# Altium schematic sheet Gatekeeper_sheet7_mic_LED_V2.1.SchDoc(Gatekeeper_sheet7_mic_LED_V2.1)
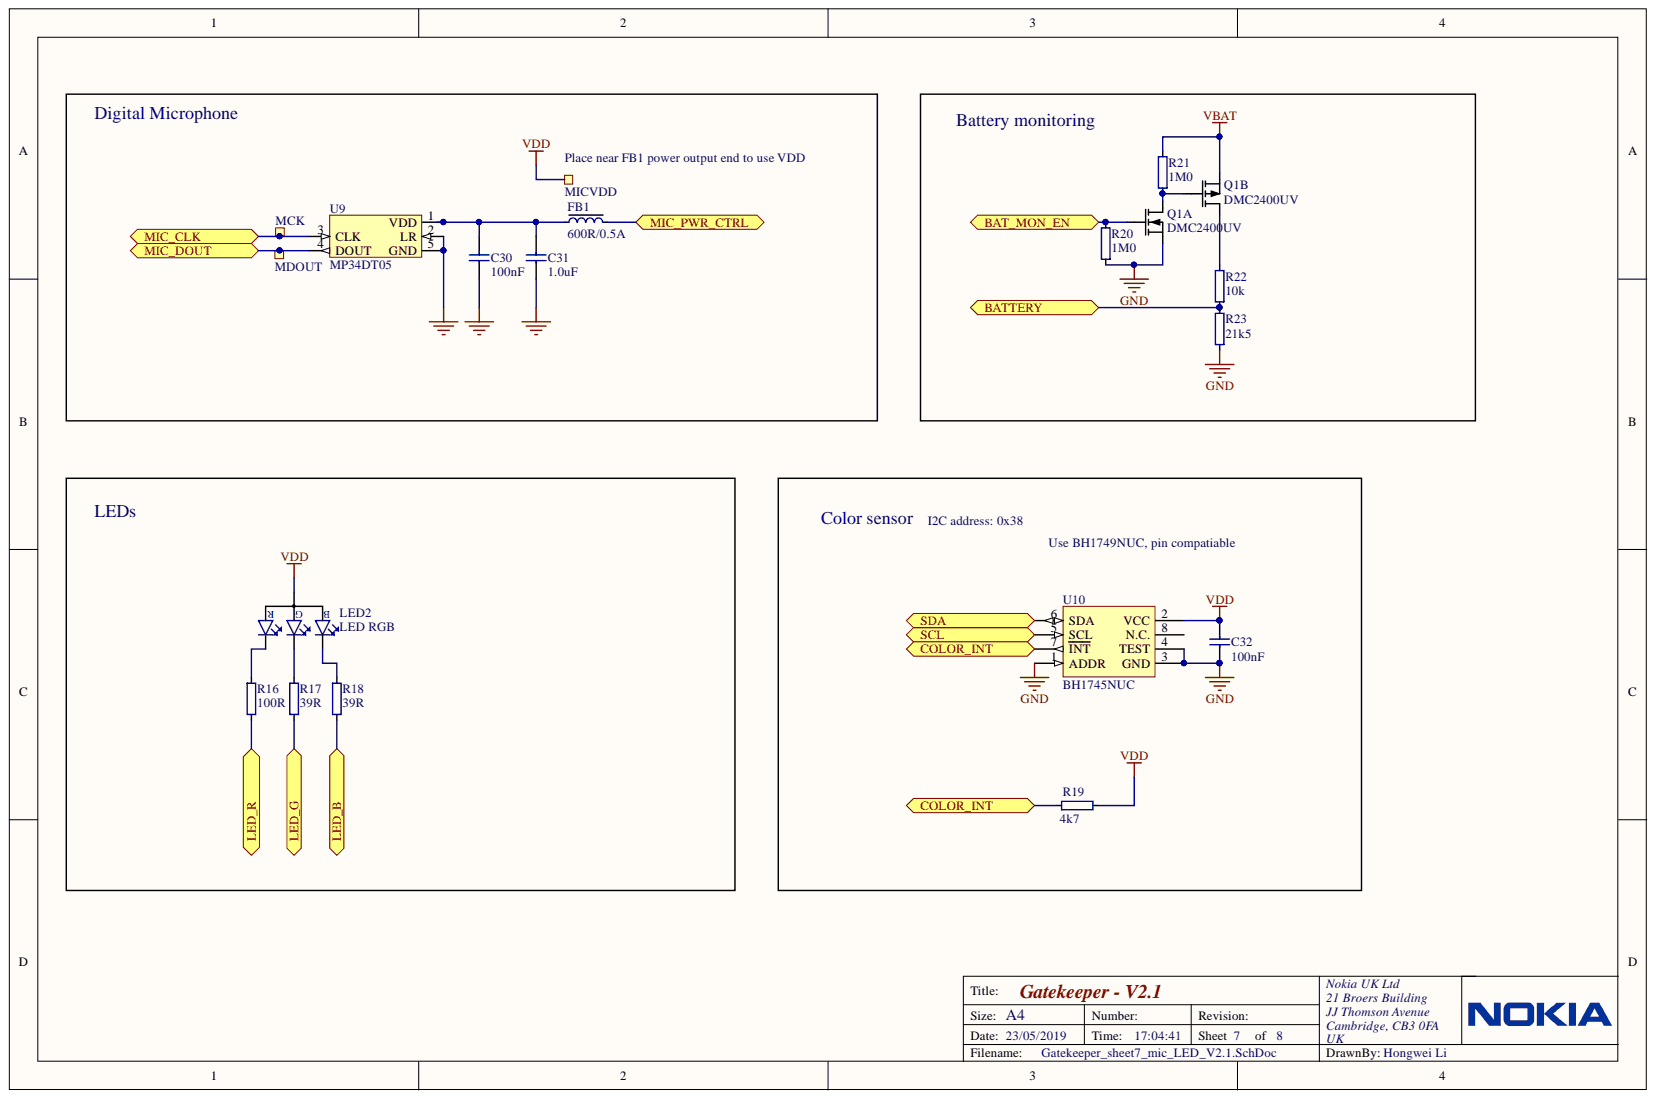
[diagram p0: Gatekeeper_sheet7_mic_LED_V2.1.SchDoc]

## Parts
C30  100nF  [CAPC1005X04L]
C31  1.0uF  [CAPC1608X06L]
C32  100nF  [CAPC1005X04L]
FB1  600R/0.5A  [INDC1608X06L]
LED2  LED RGB  [LED_RGB_0606]
MCK  Ø1.5mm,SMD  [TP_SMD_Ø1.0mm]  (value not drawn)  (no BOM)
MDOUT  Ø1.5mm,SMD  [TP_SMD_Ø1.0mm]  (value not drawn)  (no BOM)
MICVDD  =Value  [TP_SMD_SQ0.8mm]  (no BOM)
Q1  DMC2400UV  [SOTFL50P162X60-6N]  (parts A,B)
R16  100R  [RESC1005X04L]
R17  39R  [RESC1005X04L]
R18  39R  [RESC1005X04L]
R19  4k7  [RESC1005X04L]
R20  1M0  [RESC1005X04L]
R21  1M0  [RESC1005X04L]
R22  10k  [RESC1005X04L]
R23  21k5  [RESC1005X04L]
U9  MP34DT05  [HCLGA-4LD(3x4x1MM)]
U10  BH1745NUC  [WSON50P210X210X60_HS-9N]

## Ports
BAT_MON_EN, BATTERY, COLOR_INT, LED_B, LED_G, LED_R, MIC_CLK, MIC_DOUT, MIC_PWR_CTRL, SCL, SDA

## Nets
GND: C30.1 C31.1 C32.1 Q1.1 R20.2 R23.2 U9.2(LR) U9.5(GND) U10.1(ADDR) U10.3(GND) U10.4(TEST) U10.9
VBAT: Q1.4 R21.1
VDD: C32.2 LED2.4 MICVDD.1 R19.1 U10.2(VCC)
BAT_MON_EN: Q1.2 R20.1 ; port BAT_MON_EN
BATTERY: R22.2 R23.1 ; port BATTERY
COLOR_INT: R19.2 U10.7(INT) ; port COLOR_INT
LED_B: R18.1 ; port LED_B
LED_G: R17.1 ; port LED_G
LED_R: R16.1 ; port LED_R
MIC_CLK: MCK.1 U9.3(CLK) ; port MIC_CLK
MIC_DOUT: MDOUT.1 U9.4(DOUT) ; port MIC_DOUT
MIC_PWR_CTRL: FB1.2 ; port MIC_PWR_CTRL
SCL: U10.5(SCL) ; port SCL
SDA: U10.6(SDA) ; port SDA
NetC30_2: C30.2 C31.2 FB1.1 U9.1(VDD)
NetLED2_1: LED2.1 R16.2
NetLED2_2: LED2.2 R17.2
NetLED2_3: LED2.3 R18.2
NetQ1_3: Q1.3 R22.1
NetQ1_5: Q1.5 Q1.6 R21.2

Not connected: U10 8(N.C.)

## Library data of the parts
C30 C32 = (no symbol name): Capacitor, 0402, X7R, ±10%; Part Number: C0402; Manufacturer Part Number: 885012205037; Manufacturer: Wurth Electronics; 2nd source part number: 81-GCM155R71C104KA5D; Note: 16V; Value: 100nF; Supplier part number: 710-885012205037; Supplier: Mouser; Pin Count: 2; Footprint Doc: 0402; Datasheet: https://www.mouser.co.uk/datasheet/2/445/885012205037-554188.pdf; Component Kind: Standard; 2nd source Supplier: Mouser; Library Name: Gatekeeper.SCHLIB
C31 = (no symbol name): Capacitor, 0603, X7R, ±10%; Part Number: C0603-1µ0; Manufacturer Part Number: JMK107B7105KA-T; Manufacturer: Taiyo Yuden; 2nd source part number: 710-885012206002; Note: 6.3V; Value: 1.0uF; Supplier part number: 963-JMK107B7105KA-T; Supplier: Mouser; Pin Count: 2; Footprint Doc: 0603; Component Kind: Standard; 2nd source Supplier: Mouser; Library Name: Gatekeeper.SCHLIB
FB1 = (no symbol name): Ferrite Bead, 0603, 600 Ohm @ 100MHz, 500mA, 380 mOhm Max; Part number IPS: 00000007789; Part Number: FB0603-600R@100MHz-500mA; Manufacturer Part Number: BLM18AG601SN1D; Manufacturer: Murata Electronics; 2nd source part number: 490-1014-1-ND; Note: Chip EMIFIL Inductor Type Chip Ferrite Bead; Value: 600R/0.5A; Supplier Part Number: 81-BLM11A601S; Supplier: Mouser; Pin Count: 2; Footprint Doc: 0603; Datasheet: http://www.farnell.com/datasheets/356366.pdf; ComponentLink2Description: Supplier link; ComponentLink1Description: Datasheet; Component Kind: Standard; 2nd source Supplier: DigiKey; Library Name: Gatekeeper_V2.1.SchLib
LED2 = D:LED_RGB: RGB LED 1.60 mm x 1.60 mm SMD; Part number IPS: 00000007798; Part Number: LTST-C19HE1WT; Manufacturer: Lite-On Inc; 2nd source part number: 160-2162-1-ND; Value: LED RGB; Supplier Part Number: 859-LTST-C19HE1WT; Supplier: Mouser; Pin Count: 4; Manufacturer Part Number: LTST-C19HE1WT; Footprint Doc: LED_RGB_0606; Datasheet: http://optoelectronics.liteon.com/upload/download/DS22-2008-0044/S_110_LTST-C19HE1WT.pdf; Component Kind: Standard; 2nd Source Supplier: Digi-Key; Library Name: Gatekeeper_V2.1.SchLib
MCK MDOUT = TP_SMD_Ø1.0MM: 1.0mm circular SMD testpad; Part Number: TP_SMD_Ø1.5MM; Value: Ø1.5mm,SMD; Pin Count: 1; Footprint Doc: TP_SMD_Ø1.5mm; Library Name: Gatekeeper.IntLib
  MDOUT: LatestRevisionDate: 2016-06-02
MICVDD = TP_SMD: SMD testpad of various size; Library Name: Gatekeeper_V2.1.SchLib
Q1 = T:FET-N/P-DUAL: Dual N & P Ch MOFET, 1.03A/0.7A, 480mOhm, 450mW; Part Number: DMC2400UV-13; Manufacturer Part Number: DMC2400UV-7; Manufacturer: Diodes Incorporated; 2nd source part number: DMC2400UV-7DICT-ND; Note: Dual N & P Channel Digital FET; Value: DMC2400UV; Supplier part number: 621-DMC2400UV-7; Supplier: Mouser; Pin Count: 6; Footprint Doc: SOT-563; Datasheet: http://www.diodes.com/_files/datasheets/DMC2400UV.pdf; Component Kind: Standard; 2nd source Supplier: DigiKey; Library Name: Gatekeeper.SCHLIB
R16 = (no symbol name): Resistor, 0402, ±1%, 0.063W; Part Number: R0402; Manufacturer Part Number: CRCW0402100RFKEDC; Manufacturer: Vishay; Value Ohm: 100; Note: Chip Res 1%; Value: 100R; Supplier part number: 71-CRCW0402100RFKEDC; Supplier: Mouser; Pin Count: 2; Footprint Doc: 0402; Component Kind: Standard; Library Name: Gatekeeper.SCHLIB
R17 R18 = (no symbol name): Resistor, 0402, ±1%, 0.063W; Part Number: R0402; Manufacturer Part Number: CRCW040239R0FKED; Manufacturer: Vishay; Value Ohm: 39; Note: Chip Res 1%; Value: 39R; Supplier part number: 71-CRCW040239R0FKED; Supplier: Mouser; Pin Count: 2; Footprint Doc: 0402; Component Kind: Standard; Library Name: Gatekeeper.SCHLIB
R19 = (no symbol name): Resistor, 0402, ±1%, 0.063W; Part Number: R0402; Manufacturer Part Number: CRCW04024K70FKEDC; Manufacturer: Vishay; 2nd source part number: AC0402FR-074K7L; Value Ohm: 4700; Note: Chip Res 1%; Value: 4k7; Supplier part number: 71-CRCW04024K70FKEDC; Supplier: Mouser; Pin Count: 2; Footprint Doc: 0402; Datasheet: https://www.mouser.co.uk/datasheet/2/427/crcwce3-1223726.pdf; Component Kind: Standard; 2nd source Supplier: Mouser; Library Name: Gatekeeper.SCHLIB
R20 R21 = R0402-1M0: Resistor, ±1%, 0.063W; Manufacturer Part Number: CRCW04021M00FKEDC; Manufacturer: Vishay; Value Ohm: 1000000; Note: Chip Res 1%; Database Table Name: Resistors$; Value: 1M0; Supplier part number: 71-CRCW04021M00FKEDC; Supplier: Mouser; Pin Count: 2; Part Number: R0402-1M0; Library Name: Nordic Passive DB.DbLib; Footprint Doc: 0402; Component Kind: Standard
R22 = (no symbol name): Resistor, 0402, ±1%, 0.063W; Part Number: R0402; Manufacturer Part Number: ERJ-2RKF1002X; Manufacturer: Panasonic; 2nd source part number: 173-8864; Value Ohm: 10000; Note: Chip Res 1%; Value: 10k; Supplier part number: 667-ERJ-2RKF1002X; Supplier: Mouser; Pin Count: 2; Footprint Doc: 0402; Component Kind: Standard; 2nd source Supplier: Farnell; Library Name: Gatekeeper.SCHLIB
R23 = RES_1: Resistor, ±1%, 0.063W; Part Number: R0402-21k5; Manufacturer Part Number: CRCW040221K5FKED; Manufacturer: Vishay; Value Ohm: 21500; Note: Chip Res 1%; Value: 21k5; Supplier part number: 71-CRCW0402-21.5K-E3; Supplier: Mouser; Pin Count: 2; Footprint Doc: 0402; Component Kind: Standard; Library Name: Gatekeeper_V2.1.SCHLIB
U9 = DIG_MIC-MP34DT05: MEMS audio sensor omnidirectional digital microphone; Manufacturer Part Number: MP34DT05TR-A; Manufacturer: STMicroelectronics; 2nd source part number: 497-17809-1-ND; Value: MP34DT05; Supplier part number: 511-MP34DT05TR-A; Supplier: Mouser; Published: 2015-11-11; Pin Count: 5; Part Number: MP34DT05; LatestRevisionDate: 2015-11-11; Footprint Doc: HCLGA=4L; Datasheet: https://www.mouser.co.uk/datasheet/2/389/mp34dt05-a-1309419.pdf; Component Kind: Standard; 2nd source Supplier: DigiKey; Library Name: Gatekeeper.SCHLIB
U10 = BH1745NUC: Digital 16bit Serial Output Type Color Sensor IC; Manufacturer Part Number: BH1745NUC-E2; Manufacturer: Rohm Semiconductor; 2nd source part number: BH1745NUC-E2CT-ND; Value: BH1745NUC; Supplier part number: 755-BH1745NUC-E2; Supplier: Mouser; Pin Count: 8; Footprint Doc: WSON-8; Datasheet: http://rohmfs.rohm.com/en/products/databook/datasheet/ic/sensor/light/bh1745nuc-e.pdf; Component Kind: Standard; 2nd source Supplier: DigiKey; Library Name: Gatekeeper.SCHLIB
